# Altium schematic sheet Motor_Driver.SchDoc(Motor_Driver)
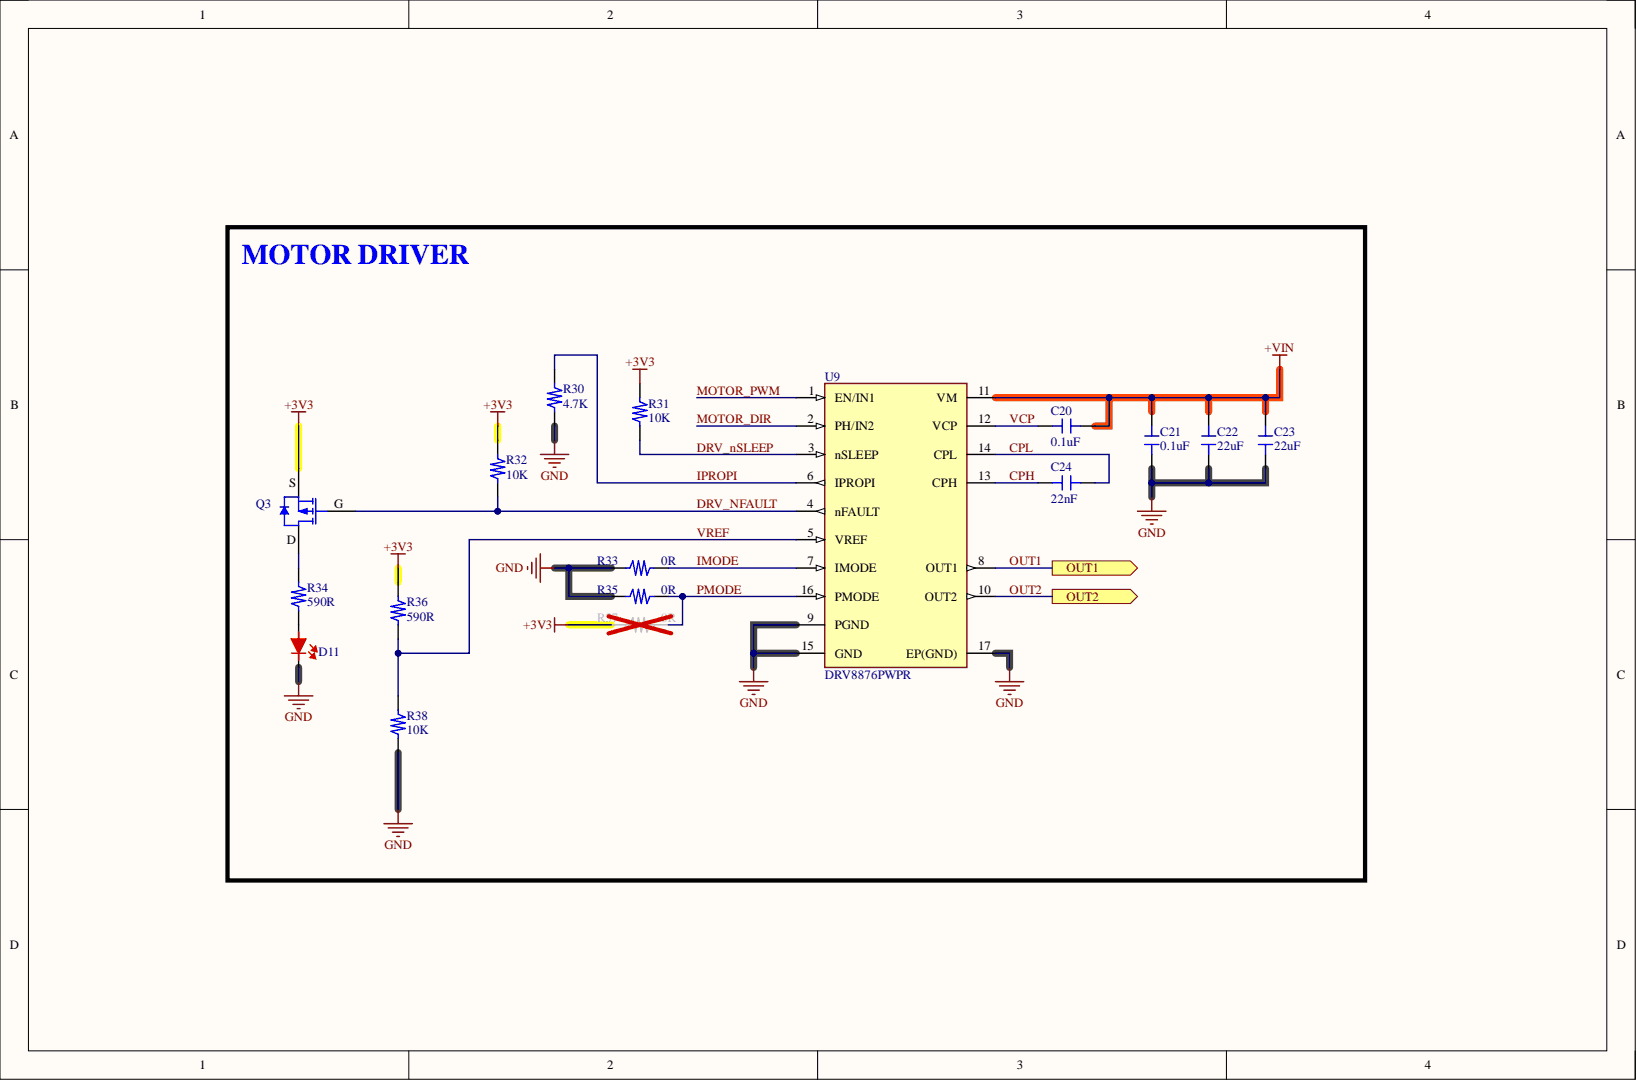
[diagram p0: Motor_Driver.SchDoc]

## Parts
C20  value 0.1uF  [CAP0603]  (hidden comment: GRM188R72A104KA35D)
C21  value 0.1uF  [CAP0603]  (hidden comment: GRM188R72A104KA35D)
C22  value 22uF  [CAP1210]  (hidden comment: GRM32ER71E226KE15L)
C23  value 22uF  [CAP1210]  (hidden comment: GRM32ER71E226KE15L)
C24  value 22nF  [CAP0603]  (hidden comment: GCM188R72A223KA37D)
D11  LS R976-NR-1-0-20-R18  [LED0805]  (value not drawn)
Q3  BSS84-7-F  [SOT23]  (value not drawn)
R30  value 4.7K  [RES0603]  (hidden comment: RC0603FR-074K7L)
R31  value 10K  [RES0603]  (hidden comment: RC0603FR-0710KL)
R32  value 10K  [RES0603]  (hidden comment: RC0603FR-0710KL)
R33  value 0R  [RES0603]  (hidden comment: RC0603FR-070RL)
R34  value 590R  [RES0603]  (hidden comment: RC0603FR-07590RL)
R35  value 0R  [RES0603]  (hidden comment: RC0603FR-070RL)
R36  value 590R  [RES0603]  (hidden comment: RC0603FR-07590RL)
R37  value 0R  [RES0603]  (hidden comment: RC0603FR-070RL)
R38  value 10K  [RES0603]  (hidden comment: RC0603FR-0710KL)
U9  DRV8876PWPR  [HTSSOP-16]

## Ports
OUT1, OUT2

## Nets
+3V3: Q3.S R31.1 R32.1 R36.1 R37.2
+VIN: C20.2 C21.1 C22.2 C23.2 U9.11(VM)
GND: C21.2 C22.1 C23.1 D11.K R30.2 R33.2 R35.2 R38.2 U9.9(PGND) U9.15(GND) U9.17(EP(GND))
CPH: C24.1 U9.13(CPH)
CPL: C24.2 U9.14(CPL)
DRV_NFAULT: Q3.G R32.2 U9.4(nFAULT)
DRV_nSLEEP: R31.2 U9.3(nSLEEP)
IMODE: R33.1 U9.7(IMODE)
IPROPI: R30.1 U9.6(IPROPI)
MOTOR_DIR: U9.2(PH/IN2)
MOTOR_PWM: U9.1(EN/IN1)
OUT1: U9.8(OUT1) ; port OUT1
OUT2: U9.10(OUT2) ; port OUT2
PMODE: R35.1 R37.1 U9.16(PMODE)
VCP: C20.1 U9.12(VCP)
VREF: R36.2 R38.1 U9.5(VREF)
NetD11_A: D11.A R34.1
NetQ3_D: Q3.D R34.2

## Library data of the parts
C20 C21 = GRM188R72A104KA35D: 0.1 µF ±10% 100V Ceramic Capacitor X7R 0603 (1608 Metric); Manufacturer: Murata Electronics; Component Kind: Standard; ComponentLink1Description: Datasheet; ComponentLink1URL: https://search.murata.co.jp/Ceramy/image/img/A01X/G101/ENG/GRM188R72A104KA35-01.pdf; ComponentLink2Description: DigiKey Link; ComponentLink2URL: https://www.digikey.com/en/products/detail/murata-electronics/GRM188R72A104KA35D/702549; ComponentLink3Description: LCSC Link; ComponentLink3URL: https://lcsc.com/product-detail/Multilayer-Ceramic-Capacitors-MLCC-SMD-SMT_muRata-GRM188R72A104KA35D_C77058.html?s_z=n_GRM188R72A104KA35D; DigiKey PN: 490-3285-1-ND; LCSC PN: C77058; Library Name: 01-Capacitors_0603.IntLib; MPN: GRM188R72A104KA35D; Package: 0603; Temp Coefficient: X7R; Tolerance: ±10%; Voltage Rating: 100V
C22 C23 = GRM32ER71E226KE15L: 22 µF ±10% 25V Ceramic Capacitor X7R 1210 (3225 Metric); Manufacturer: Murata Electronics; Component Kind: Standard; ComponentLink1Description: Datasheet; ComponentLink1URL: https://search.murata.co.jp/Ceramy/image/img/A01X/G101/ENG/GRM32ER71E226KE15-01.pdf; ComponentLink2Description: DigiKey Link; ComponentLink2URL: https://www.digikey.co.il/en/products/detail/murata-electronics/GRM32ER71E226KE15L/2039040?s=N4IgTCBcDaIOICUCyBmMBRBB2AjOsYAbANLo4CsAMiALoC%2BQA; ComponentLink3Description: LCSC Link; ComponentLink3URL: https://lcsc.com/product-detail/Multilayer-Ceramic-Capacitors-MLCC-SMD-SMT_Murata-Electronics-GRM32ER71E226KE15L_C21397.html; DigiKey PN: 490-5313-1-ND; LCSC PN: C21397; Library Name: 01-Capacitors_1210.IntLib; MPN: GRM32ER71E226KE15L; Package: 1210; Temp Coefficient: X7R; Tolerance: ±10%; Voltage Rating: 25V
C24 = GCM188R72A223KA37D: 0.022 µF ±10% 100V Ceramic Capacitor X7R 0603 (1608 Metric); Manufacturer: Murata Electronics; Component Kind: Standard; ComponentLink1Description: Datasheet; ComponentLink1URL: https://pim.murata.com/en-us/pim/details/?partNum=GCM188R72A223KA37D; ComponentLink2Description: DigiKey Link; ComponentLink2URL: https://www.digikey.com/en/products/detail/murata-electronics/GCM188R72A223KA37D/1641656; ComponentLink3Description: LCSC Link; ComponentLink3URL: https://www.lcsc.com/product-detail/C161234.html?s_z=n_GCM188R72A223KA37D; DigiKey PN: 490-4782-1-ND; LCSC PN: C161234; Library Name: 01-Capacitors_0603.IntLib; MPN: GCM188R72A223KA37D; Package: 0603; Temp Coefficient: X7R; Tolerance: ±10%; Voltage Rating: 100V
D11 = LS R976-NR-1-0-20-R18: Red 633nm LED Indication - Discrete 2V 0805 (2012 Metric); Manufacturer: ams-OSRAM USA INC.; Component Kind: Standard; ComponentLink1Description: Datasheet; ComponentLink1URL: https://look.ams-osram.com/m/330c725e4ebb09ab/original/LS-R976.pdf; ComponentLink2Description: DigiKey Link; ComponentLink2URL: https://www.digikey.com/en/products/detail/ams-osram-usa-inc/LS-R976-NR-1-0-20-R18/1227987?s=N4IgjCBcpgbFoDGUBmBDANgZwKYBoQB7KAbRAGYBOcgJkpAF0CAHAFyhAGVWAnASwB2AcxABfAuQDsCEMkjps%2BIqQqUArDTD0mINh279hYgloAc9aLNSZcBYpDIAGRuJABaCJbkLbyhyAAWSTUXAjcaGTleAFclezIQhlc3eC8oGLiVchdRUSA; ComponentLink3Description: LCSC Link; ComponentLink3URL: https://lcsc.com/product-detail/LED-Indication-Discrete_OSRAM-LS-R976-NR-1-0-20-R18_C2899997.html?s_z=n_LS%2520R976-NR-1-0-20-R18; DigiKey PN: 475-LSR976-NR-1CT-ND; LCSC PN: C2899997; Library Name: 05-Optoelectronics.IntLib; MPN: LS R976-NR-1-0-20-R18; Package: 0805
Q3 = BSS84-7-F: MOSFET P-CH 50V 130MA SOT23-3; Manufacturer: Diodes Incorporated; Component Kind: Standard; ComponentLink1Description: Datasheet; ComponentLink1URL: https://www.diodes.com/assets/Datasheets/BSS84.pdf; ComponentLink2Description: DigiKey Link; ComponentLink2URL: https://www.digikey.co.il/en/products/detail/diodes-incorporated/BSS84-7-F/717724; ComponentLink3Description: LCSC Link; ComponentLink3URL: https://lcsc.com/product-detail/MOSFETs_Diodes-Incorporated-BSS84-7-F_C85202.html?s_z=n_BSS84-7-F; DigiKey PN: BSS84-FDICT-ND; LCSC PN: C85202; Library Name: 03-Transistors_MOSFETS.IntLib; MPN: BSS84-7-F; Package: SOT23-3
R30 = RC0603FR-074K7L: 4.7 kOhms ±1% 0.1W, 1/10W Chip Resistor 0603 (1608 Metric) Moisture Resistant Thick Film; Manufacturer: YAGEO; Component Kind: Standard; ComponentLink1Description: Datasheet; ComponentLink1URL: https://www.yageo.com/upload/media/product/products/datasheet/rchip/PYu-RC_Group_51_RoHS_L_12.pdf; ComponentLink2Description: DigiKey Link; ComponentLink2URL: https://www.digikey.com/en/products/detail/yageo/RC0603FR-074K7L/727212?s=N4IgTCBcDaIEoGEAMA2JBmAYnAtEg7ACwDS%2BAMiALoC%2BQA; ComponentLink3Description: LCSC Link; ComponentLink3URL: https://lcsc.com/product-detail/Chip-Resistor-Surface-Mount_YAGEO-RC0603FR-074K7L_C99782.html?s_z=n_RC0603FR-074K7L; DigiKey PN: 311-4.70KHRCT-ND; LCSC PN: C99782; Library Name: 00-Resistors_0603.IntLib; MPN: RC0603FR-074K7L; Package: 0603
R31 R32 R38 = RC0603FR-0710KL: 10 kOhms ±1% 0.1W, 1/10W Chip Resistor 0603 (1608 Metric) Moisture Resistant Thick Film; Manufacturer: YAGEO; Component Kind: Standard; ComponentLink1Description: Datasheet; ComponentLink1URL: https://www.yageo.com/upload/media/product/products/datasheet/rchip/PYu-RC_Group_51_RoHS_L_12.pdf; ComponentLink2Description: DigiKey Link; ComponentLink2URL: https://www.digikey.com/en/products/detail/yageo/RC0603FR-0710KL/726880?s=N4IgTCBcDaIEoGEAMA2JBmAYnAtEg7AIxIDSAMiALoC%2BQA; ComponentLink3Description: LCSC Link; ComponentLink3URL: https://lcsc.com/product-detail/Chip-Resistor-Surface-Mount_YAGEO-RC0603FR-0710KL_C98220.html?s_z=n_RC0603FR-0710KL; DigiKey PN: 311-10.0KHRCT-ND; LCSC PN: C98220; Library Name: 00-Resistors_0603.IntLib; MPN: RC0603FR-0710KL; Package: 0603
R33 R35 R37 = RC0603FR-070RL: 0 Ohms Jumper Chip Resistor 0603 (1608 Metric) Moisture Resistant Thick Film; Manufacturer: YAGEO; Component Kind: Standard; ComponentLink1Description: Datasheet; ComponentLink1URL: https://www.yageo.com/upload/media/product/products/datasheet/rchip/PYu-RC_Group_51_RoHS_L_12.pdf; ComponentLink2Description: DigiKey Link; ComponentLink2URL: https://www.digikey.com/en/products/detail/yageo/RC0603FR-070RL/1304008?s=N4IgTCBcDaIEoGEAMA2JBmAYnAtEg7EnADIgC6AvkA; ComponentLink3Description: LCSC Link; ComponentLink3URL: https://lcsc.com/product-detail/Chip-Resistor-Surface-Mount_YAGEO-RC0603FR-070RL_C100044.html?s_z=n_RC0603FR-070RL; DigiKey PN: 311-0.0HRCT-ND; LCSC PN: C100044; Library Name: 00-Resistors_0603.IntLib; MPN: RC0603FR-070RL; Package: 0603
R34 R36 = RC0603FR-07590RL: 590 Ohms ±1% 0.1W, 1/10W Chip Resistor 0603 (1608 Metric) Moisture Resistant Thick Film; Manufacturer: YAGEO; Component Kind: Standard; ComponentLink1Description: Datasheet; ComponentLink1URL: https://www.yageo.com/upload/media/product/products/datasheet/rchip/PYu-RC_Group_51_RoHS_L_12.pdf; ComponentLink2Description: DigiKey Link; ComponentLink2URL: https://www.digikey.com/en/products/detail/yageo/RC0603FR-07590RL/727314?s=N4IgTCBcDaIEoGEAMA2JBmAYnAtEg7AKwCcScAMiALoC%2BQA; ComponentLink3Description: LCSC Link; ComponentLink3URL: https://lcsc.com/product-detail/Chip-Resistor-Surface-Mount_YAGEO-RC0603FR-07590RL_C273786.html?s_z=n_RC0603FR-07590RL; DigiKey PN: 311-590HRCT-ND; LCSC PN: C273786; Library Name: 00-Resistors_0603.IntLib; MPN: RC0603FR-07590RL; Package: 0603
U9 = DRV8876PWPR: Unipolar Motor Driver NMOS PWM 16-HTSSOP; Manufacturer: Texas Instruments; Component Kind: Standard; ComponentLink1Description: Datasheet; ComponentLink1URL: https://www.ti.com/general/docs/suppproductinfo.tsp?distId=10&gotoUrl=https%3A%2F%2Fwww.ti.com%2Flit%2Fgpn%2Fdrv8876; ComponentLink2Description: DigiKey Link; ComponentLink2URL: https://www.digikey.co.il/en/products/detail/texas-instruments/DRV8876PWPR/10270191?s=N4IgTCBcDaICICUBqAOFB2AbABQOrYRAF0BfIA; ComponentLink3Description: LCSC Link; ComponentLink3URL: https://lcsc.com/product-detail/Brushed-DC-Motor-Drivers_Texas-Instruments-DRV8876PWPR_C575551.html?s_z=n_DRV8876PWPR; DigiKey PN: 296-53515-1-ND; LCSC PN: C575551; Library Name: 08-Drivers.IntLib; MPN: DRV8876PWPR; Package: HTSSOP-16
